# Altium schematic sheet MAIN-ELOAD.SchDoc
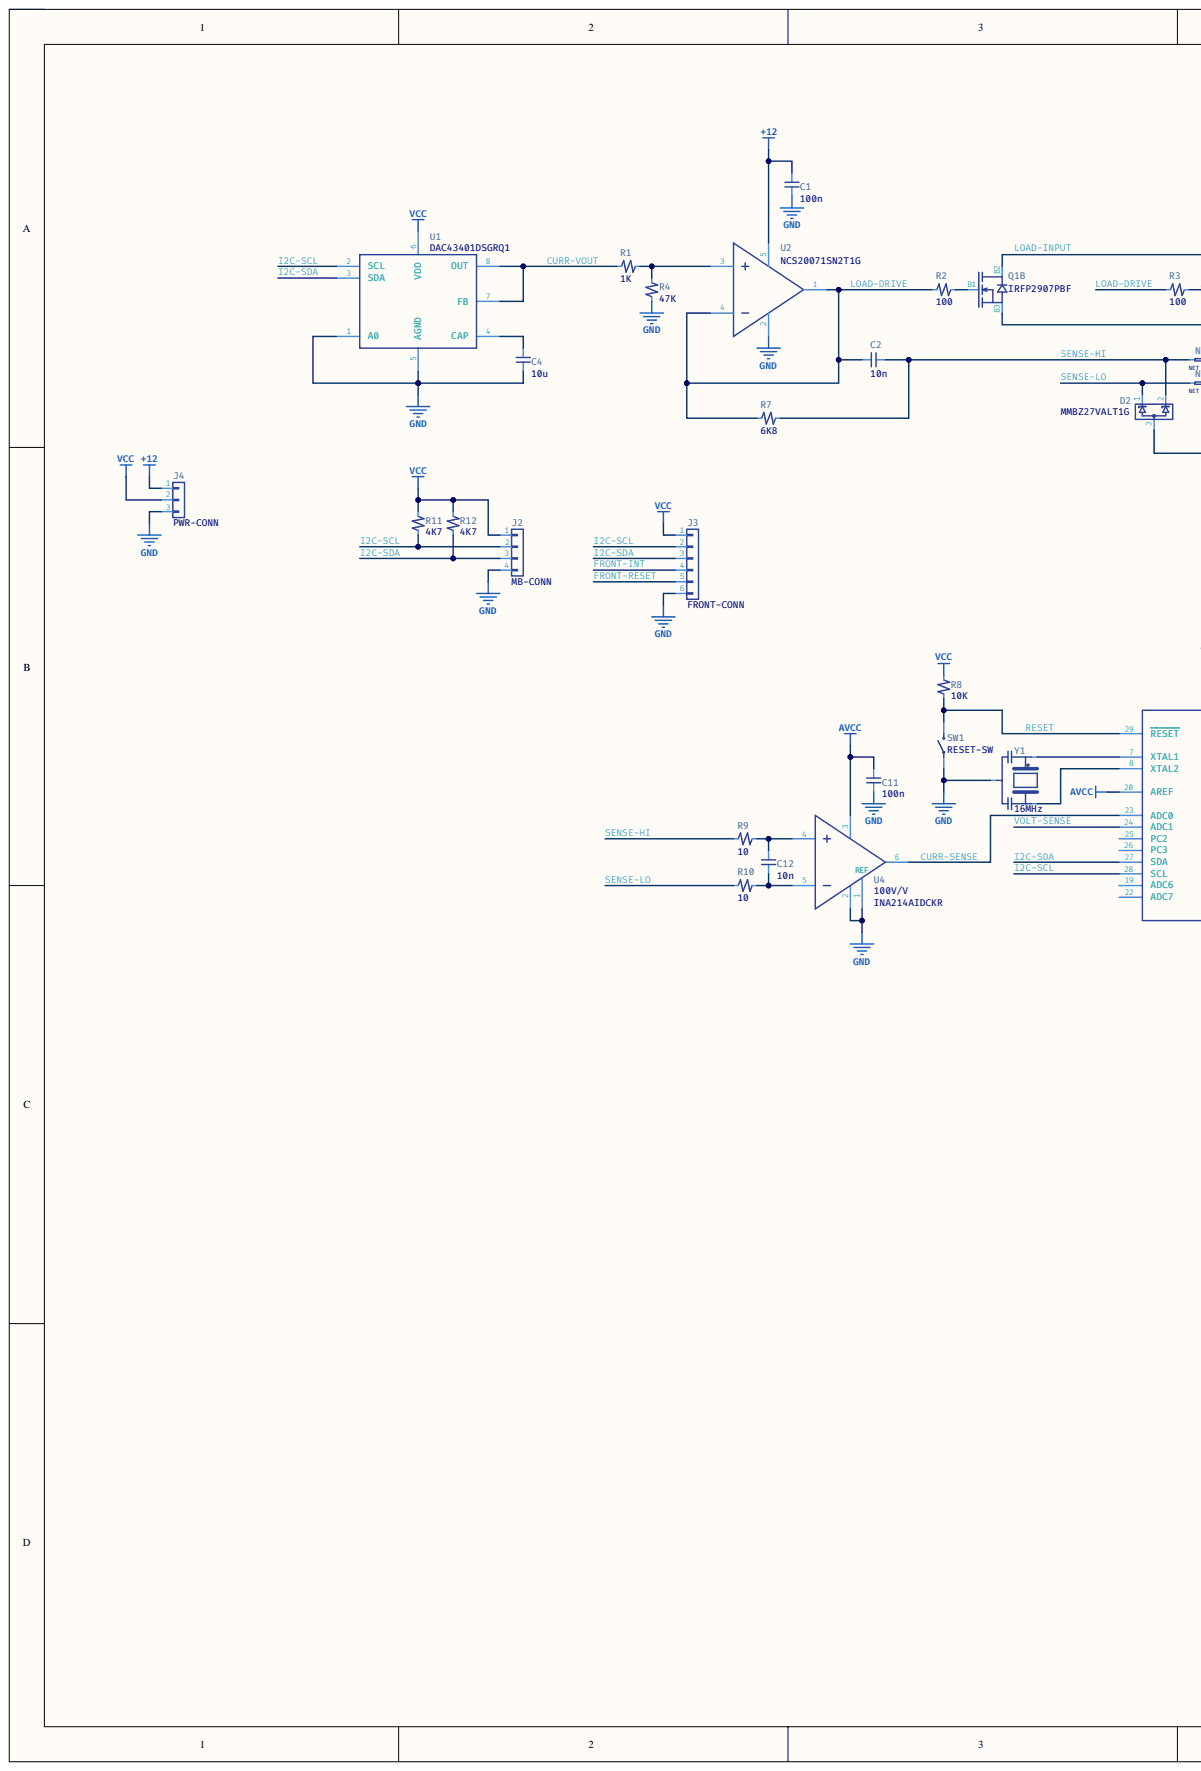
[diagram p0: MAIN-ELOAD.SchDoc, left]
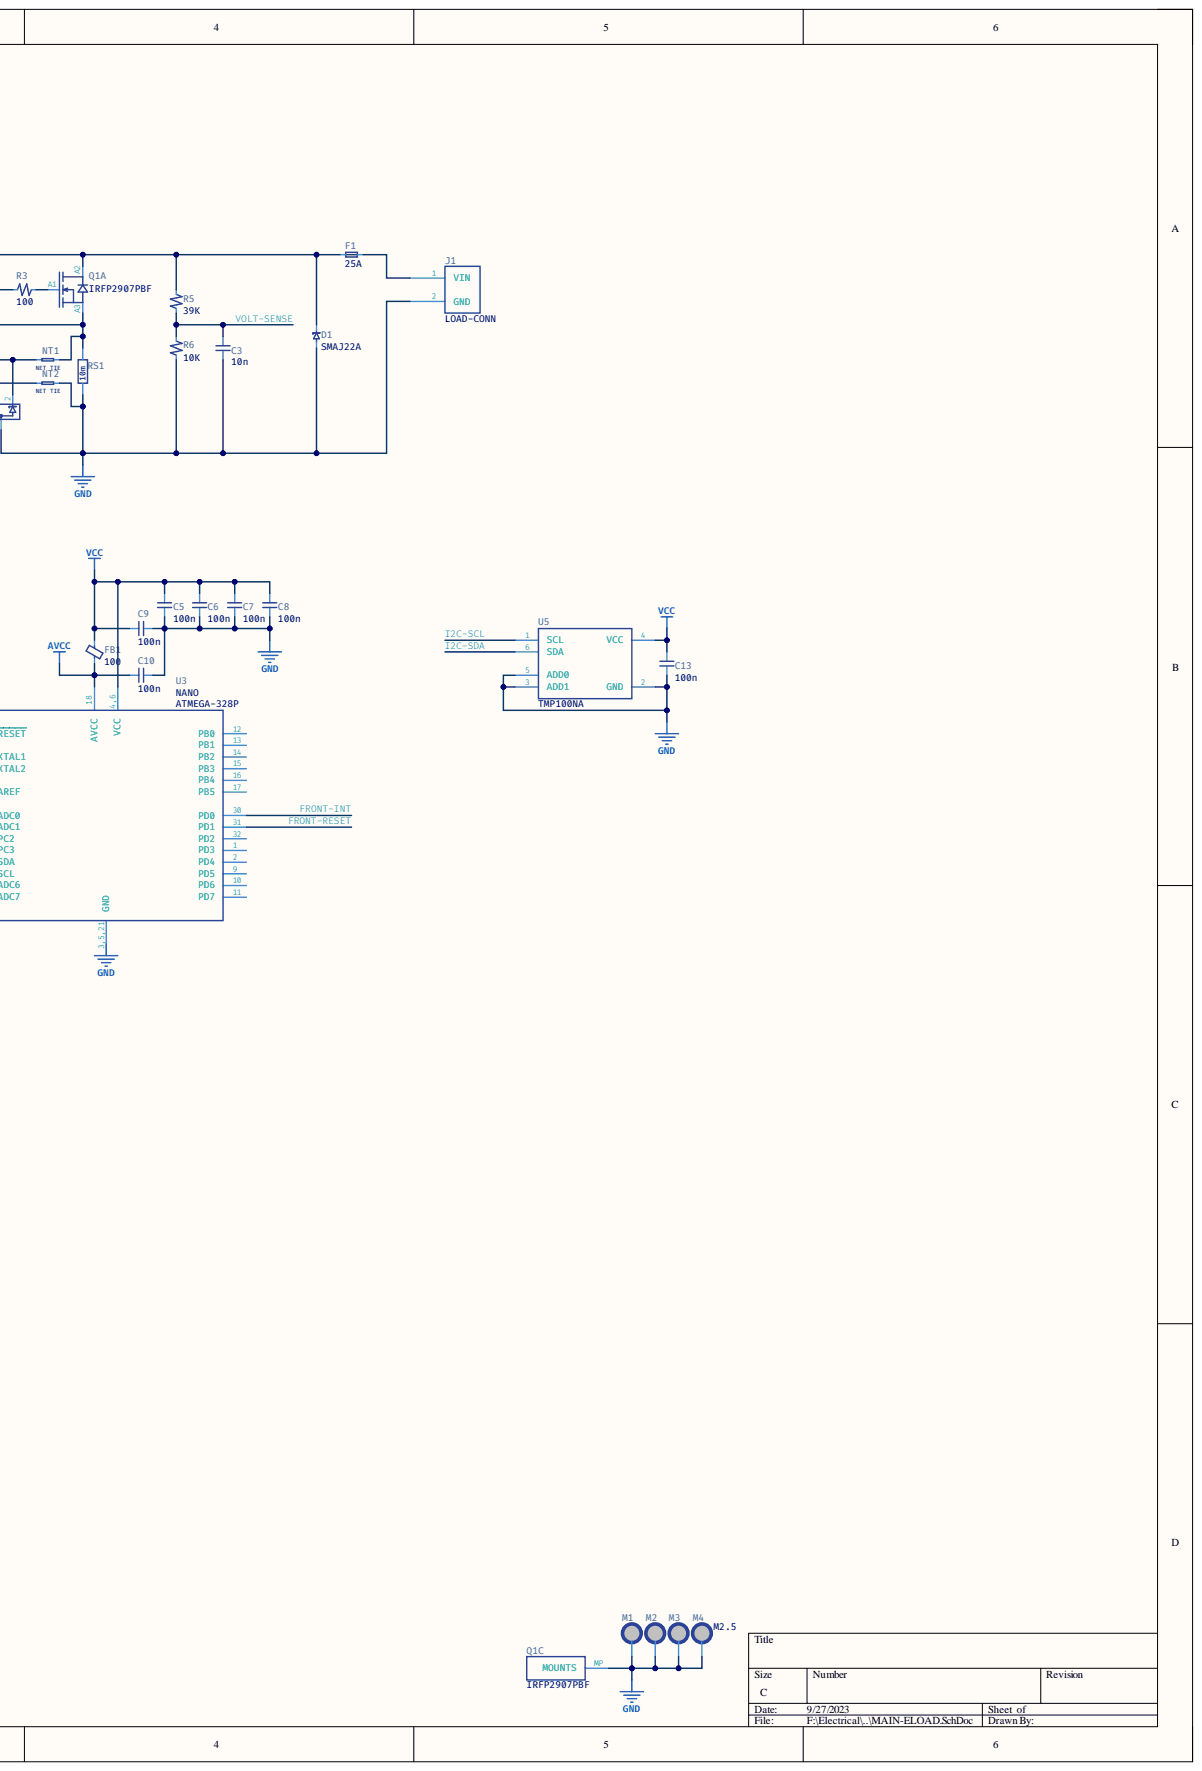
[diagram p1: MAIN-ELOAD.SchDoc, right]

## Parts
C1  value 100n  [CAP-0603]  (hidden comment: Generic Capacitor)
C2  value 10n  [CAP-0402]  (hidden comment: Generic Capacitor)
C3  value 10n  [CAP-0402]  (hidden comment: Generic Capacitor)
C4  value 10u  [CAP-0805]  (hidden comment: Generic Capacitor)
C5  value 100n  [CAP-0402]  (hidden comment: Generic Capacitor)
C6  value 100n  [CAP-0402]  (hidden comment: Generic Capacitor)
C7  value 100n  [CAP-0402]  (hidden comment: Generic Capacitor)
C8  value 100n  [CAP-0402]  (hidden comment: Generic Capacitor)
C9  value 100n  [CAP-0402]  (hidden comment: Generic Capacitor)
C10  value 100n  [CAP-0402]  (hidden comment: Generic Capacitor)
C11  value 100n  [CAP-0402]  (hidden comment: Generic Capacitor)
C12  value 10n  [CAP-0402]  (hidden comment: Generic Capacitor)
C13  value 100n  [CAP-0402]  (hidden comment: Generic Capacitor)
D1  value SMAJ22A  [D_SMA]  (hidden comment: TVS DIODE 22VWM 35.5VC)
D2  value MMBZ27VALT1G  [SOT-23-3]  (hidden comment: 2-CH TVS DIODE 22VWM 40VC)
F1  value 25A  [3557-10]  (hidden comment: Generic Fuse)
FB1  value 100  [IND-0603]  (hidden comment: Generic Ferrite Bead)
J1  value LOAD-CONN  [1720430202]  (hidden comment: PWR-CONN-2)
J2  value MB-CONN  [JST_XH_B4B-XH-A_1x04_P2.50mm_Vertical]  (hidden comment: Conn_01x04)
J3  value FRONT-CONN  [JST_XH_B6B-XH-A_1x06_P2.50mm_Vertical]  (hidden comment: Conn_01x06)
J4  PWR CONN  value PWR-CONN  [JST_XH_B3B-XH-A_1x03_P2.50mm_Vertical]
M1  Plated Mounting Hole  [PTH-MOUNT-M2.5-VIA]  (value not drawn)
M2  Plated Mounting Hole  [PTH-MOUNT-M2.5-VIA]  (value not drawn)
M3  Plated Mounting Hole  [PTH-MOUNT-M2.5-VIA]  (value not drawn)
M4  value M2.5  [PTH-MOUNT-M2.5-VIA]  (hidden comment: Plated Mounting Hole)
NT1  NET-TIE  value NET TIE  [Net-Tie-0.22mm]
NT2  NET-TIE  value NET TIE  [Net-Tie-0.22mm]
Q1  value IRFP2907PBF  [TO-247-DUAL-HS]  (hidden comment: MOSFET N-CH 75V 209A)  (parts A,B,C)
R1  value 1K  [RES-0402]  (hidden comment: General Resistor)
R2  value 100  [RES-0402]  (hidden comment: General Resistor)
R3  value 100  [RES-0402]  (hidden comment: General Resistor)
R4  value 47K  [RES-0402]  (hidden comment: General Resistor)
R5  value 39K  [RES-0603]  (hidden comment: General Resistor)
R6  value 10K  [RES-0603]  (hidden comment: General Resistor)
R7  value 6K8  [RES-0402]  (hidden comment: General Resistor)
R8  value 10K  [RES-0402]  (hidden comment: General Resistor)
R9  value 10  [RES-0402]  (hidden comment: General Resistor)
R10  value 10  [RES-0402]  (hidden comment: General Resistor)
R11  value 4K7  [RES-0402]  (hidden comment: General Resistor)
R12  value 4K7  [RES-0402]  (hidden comment: General Resistor)
RS1  value 10m  [RES-2818]  (hidden comment: General Shunt Resistor)
SW1  value RESET-SW  [TL3365AF180QG]  (hidden comment: Generic STSP Switch)
U1  value DAC43401DSGRQ1  [DFN-8_2x2mm_P0.5mm]  (hidden comment: IC DAC 8BIT V-OUT)
U2  value NCS20071SN2T1G  [TSOP-5_1.65x3.05mm_P0.95mm]  (hidden comment: IC OPAMP GP 1 CIRCUIT)
U3  ATMEGA-328P  Arduino: NANO  [TQFP-32_7x7mm_P0.8mm]
U4  value INA214AIDCKR  Gain: 100V/V  [SOT-363_SC-70-6]  (hidden comment: IC CURR SENSE 1 CIRCUIT)
U5  value TMP100NA  [SOT-23-6]  (hidden comment: SENSOR DIGITAL -55C-125C)
Y1  value 16MHz  [RESO-3-3x1.1mm]  (hidden comment: General 3P Resonator)

## Nets
+12: C1.2 J4.1 U2.5
AVCC: C10.2 C11.1 FB1.1 U3.18(AVCC) U3.20(AREF) U4.3
GND: C1.1 C3.2 C4.1 C5.2 C6.2 C7.2 C8.2 C9.1 C10.1 C11.2 C13.2 D1.2 D2.3 J1.2 J2.4 J3.6 J4.3 M1.1 M2.1 M3.1 M4.1 NT2.2 Q1.MP(MOUNTS) R4.2 R6.1 RS1.1 SW1.1 U1.1(A0) U1.5(AGND) U2.2 U3.3,5,21(GND) U4.1 U4.2 U5.2(GND) U5.3(ADD1) U5.5(ADD0) Y1.2
VCC: C5.1 C6.1 C7.1 C8.1 C9.2 C13.1 FB1.2 J2.1 J3.1 J4.2 R8.1 R11.1 R12.1 U1.6(VDD) U3.4,6(VCC) U5.4(VCC)
CURR-SENSE: U3.23(ADC0) U4.6
CURR-VOUT: R1.2 U1.7(FB) U1.8(OUT)
FRONT-INT: J3.4 U3.30(PD0)
FRONT-RESET: J3.5 U3.31(PD1)
I2C-SCL: J2.2 J3.2 R11.2 U1.2(SCL) U3.28(SCL) U5.1(SCL)
I2C-SDA: J2.3 J3.3 R12.2 U1.3(SDA) U3.27(SDA) U5.6(SDA)
LOAD-DRIVE: C2.1 R2.1 R3.1 R7.2 U2.1 U2.4
LOAD-INPUT: D1.1 F1.1 Q1.A2 Q1.B2 R5.2
RESET: R8.2 SW1.2 U3.29(RESET)
SENSE-HI: C2.2 D2.2 NT1.1 R7.1 R9.2
SENSE-LO: D2.1 NT2.1 R10.2
VOLT-SENSE: C3.1 R5.1 R6.2 U3.24(ADC1)
NetC4_2: C4.2 U1.4(CAP)
NetC12_1: C12.1 R9.1 U4.4
NetC12_2: C12.2 R10.1 U4.5
NetF1_2: F1.2 J1.1
NetNT1_2: NT1.2 Q1.A3 Q1.B3 RS1.2
NetQ1_A1: Q1.A1 R3.2
NetQ1_B1: Q1.B1 R2.2
NetR1_1: R1.1 R4.1 U2.3
NetU3_7: U3.7(XTAL1) Y1.1
NetU3_8: U3.8(XTAL2) Y1.3

Not connected: U3 1(PD3) 2(PD4) 9(PD5) 10(PD6) 11(PD7) 12(PB0) 13(PB1) 14(PB2) 15(PB3) 16(PB4) 17(PB5) 19(ADC6) 22(ADC7) 25(PC2) 26(PC3) 32(PD2)

## Library data of the parts
C1-C13 = C: General un-polarized capacitor; Tolerance: 5%; Component Kind: Standard; Library Name: Daxxn_Device.SchLib
D1 = SMAJ22A: 35.5V Clamp 11.3A Ipp Tvs Diode Surface Mount SMA; Component Kind: Standard; ComponentLink1Description: Datasheet; ComponentLink1URL: https://www.littelfuse.com/~/media/electronics/datasheets/tvs_diodes/littelfuse_tvs_diode_smaj_datasheet.pdf.pdf; ComponentLink2Description: LocalDatasheet; ComponentLink2URL: F:\Electrical\Datasheets\SMAJ22CA.pdf; Library Name: Daxxn_Protection.SchLib
D2 = MMBZ27VALT1G: 40V Clamp 1A Ipp Tvs Diode Surface Mount SOT-23; Component Kind: Standard; ComponentLink1Description: Datasheet; ComponentLink1URL: https://www.onsemi.com/pdf/datasheet/mmbz5v6alt1-d.pdf; ComponentLink2Description: LocalDatasheet; Supplier 1: Digi-Key; Supplier 2: Digi-Key; Supplier 3: Digi-Key; Supplier 4: Mouser; Supplier 5: Newark; Supplier Part Number 1: MMBZ27VALT1GOSCT-ND; Supplier Part Number 2: MMBZ27VALT1GOSDKR-ND; Supplier Part Number 3: MMBZ27VALT1GOSTR-ND; Supplier Part Number 4: 863-MMBZ27VALT1G; Supplier Part Number 5: 58M9163; ComponentLink2URL: F:\Electrical\Datasheets\MMBZ5V6ALT1.PDF; Library Name: Daxxn_Protection.SchLib
F1 = Fuse: Component Kind: Standard; Description: Generic Fuse; Library Name: Daxxn_Device.SchLib
FB1 = FerriteBead: Component Kind: Standard; Description: Generic Ferrite Bead; Library Name: Daxxn_Device.SchLib
J4 = Conn_01x03: Component Kind: Standard; ComponentLink1Description: Datasheet; ComponentLink2Description: LocalDatasheet; Library Name: Connector_Generic.SchLib
M1-M3 = MountingHole-PTH: Generic Plated Mounting Through Hole; Value: M2.5; Component Kind: Standard; ComponentLink1Description: Datasheet; ComponentLink2Description: LocalDatasheet; Library Name: Daxxn_Device.SchLib
M4 = MountingHole-PTH: Generic Plated Mounting Through Hole; Component Kind: Standard; ComponentLink1Description: Datasheet; ComponentLink2Description: LocalDatasheet; Library Name: Daxxn_Device.SchLib
Q1 = IRFP2907PBF-DUAL-HS: N-Channel 75 V 209A (Tc) 470W (Tc) Through Hole TO-247AC; Component Kind: Standard; ComponentLink1Description: Datasheet; ComponentLink1URL: https://www.infineon.com/dgdl/irfp2907pbf.pdf?fileId=5546d462533600a401535628b2d51fe8; ComponentLink2Description: LocalDatasheet; Supplier 1: Digi-Key; Supplier 2: Mouser; Supplier 3: Newark; Supplier Part Number 1: IRFP2907PBF-ND; Supplier Part Number 2: 942-IRFP2907PBF; Supplier Part Number 3: 64AH3708; ComponentLink2URL: F:\Electrical\Datasheets\IRFP2907.pdf; Library Name: Daxxn_Transistors.SchLib
R1-R12 = R: General purpose resistor; Tolerance: 1%; Component Kind: Standard; Library Name: Daxxn_Device.SchLib
RS1 = R-SH: General shunt resistor for current measurement.; Tolerance: 1%; Component Kind: Standard; ComponentLink1Description: Datasheet; ComponentLink2Description: LocalDatasheet; Library Name: Daxxn_Device.SchLib
SW1 = Switch-STSP: Component Kind: Standard; Description: Generic STSP Switch; Library Name: Daxxn_Device.SchLib
U1 = DAC43401DSGRQ1: 8 Bit Digital to Analog Converter 1 WSON-8; Component Kind: Standard; ComponentLink1Description: Datasheet; ComponentLink1URL: https://www.ti.com/lit/ds/symlink/dac43401-q1.pdf; ComponentLink2Description: LocalDatasheet; ComponentLink2URL: F:\Electrical\Datasheets\DAC43401.pdf; Library Name: Daxxn_IC.SchLib
U2 = NCS20071SN2T1G: General Purpose Amplifier 1 Circuit Rail-to-Rail TSOP-5; Component Kind: Standard; ComponentLink1Description: Datasheet; ComponentLink1URL: https://www.onsemi.com/pdf/datasheet/ncs20071-d.pdf; ComponentLink2Description: LocalDatasheet; Supplier 1: Digi-Key; Supplier 2: Digi-Key; Supplier 3: Digi-Key; Supplier 4: Mouser; Supplier 5: Newark; Supplier 6: Newark; Supplier Part Number 1: NCS20071SN2T1GOSCT-ND; Supplier Part Number 2: NCS20071SN2T1GOSDKR-ND; Supplier Part Number 3: NCS20071SN2T1GOSTR-ND; Supplier Part Number 4: 863-NCS20071SN2T1G; Supplier Part Number 5: 31AC0667; Supplier Part Number 6: 31AC6695; ComponentLink2URL: F:\Electrical\Datasheets\NCS20071.PDF; Library Name: Daxxn_OPAMP.SchLib
U4 = INA214: High/Low Side Current Sense Amplifier 1 Circuit Single-Ended Sense SC-70-6; Component Kind: Standard; ComponentLink1Description: Datasheet; ComponentLink1URL: https://www.ti.com/lit/ds/symlink/ina211.pdf?HQS=dis-dk-null-digikeymode-dsf-pf-null-wwe&ts=1690640591645&ref_url=https%253A%252F%252Fwww.ti.com%252Fgeneral%252Fdocs%252Fsuppproductinfo.tsp%253FdistId%253D10%2526gotoUrl%253Dhttps%253A%252F%252Fwww.ti.com%252Flit%252Fgpn%252Fina211; ComponentLink2Description: LocalDatasheet; ComponentLink2URL: F:\Electrical\Datasheets\INA211.pdf; Library Name: Daxxn_IC.SchLib
U5 = TMP100NA: Temperature Sensor Digital, Local -55°C ~ 125°C 11 b SOT-23-6; Component Kind: Standard; ComponentLink1Description: Datasheet; ComponentLink1URL: https://www.ti.com/lit/ds/symlink/tmp100.pdf?HQS=dis-dk-null-digikeymode-dsf-pf-null-wwe&ts=1687660469406&ref_url=https%253A%252F%252Fwww.ti.com%252Fgeneral%252Fdocs%252Fsuppproductinfo.tsp%253FdistId%253D10%2526gotoUrl%253Dhttps%253A%252F%252Fwww.ti.com%252Flit%252Fgpn%252Ftmp100; ComponentLink2Description: LocalDatasheet; ComponentLink2URL: F:\Electrical\Datasheets\TMP100.pdf; Library Name: Daxxn_Sensors.SchLib
Y1 = Resonator: General 3-Pin Resonator; Tolerance: 15ppm; Component Kind: Standard; ComponentLink1Description: Datasheet; ComponentLink2Description: LocalDatasheet; Library Name: Daxxn_Device.SchLib
J1 = PWR-CONN-2: Component Kind: Standard; ComponentLink1Description: Datasheet; ComponentLink2Description: LocalDatasheet; Library Name: Daxxn_Connectors.SchLib
J2 = Conn_01x04: Component Kind: Standard; ComponentLink1Description: Datasheet; ComponentLink2Description: LocalDatasheet; Library Name: Connector_Generic.SchLib
J3 = Conn_01x06: Component Kind: Standard; ComponentLink1Description: Datasheet; ComponentLink2Description: LocalDatasheet; Library Name: Connector_Generic.SchLib
NT1 NT2 = NET-TIE: Component Kind: Net Tie; ComponentLink1Description: Datasheet; ComponentLink2Description: LocalDatasheet; Library Name: Daxxn_Other.SchLib
U3 = ATMEGA-328P: Component Kind: Standard; ComponentLink1Description: Datasheet; ComponentLink2Description: LocalDatasheet; ComponentLink3Description: ArduinoPinout; ComponentLink4Description: ArduinoSchematic; Value: ATMEGA-328P; ComponentLink2URL: F:\Electrical\Datasheets\ATMEGA-328P.pdf; ComponentLink3URL: F:\Electrical\Arduino Schematics\NANO\Nano Pinout.png; ComponentLink4URL: F:\Electrical\Arduino Schematics\NANO\ARDUINO-NANO-SCH.pdf; Library Name: Daxxn_MicroControllers.SchLib
